# Altium schematic sheet PWM_Signal_Amplifiers.SchDoc
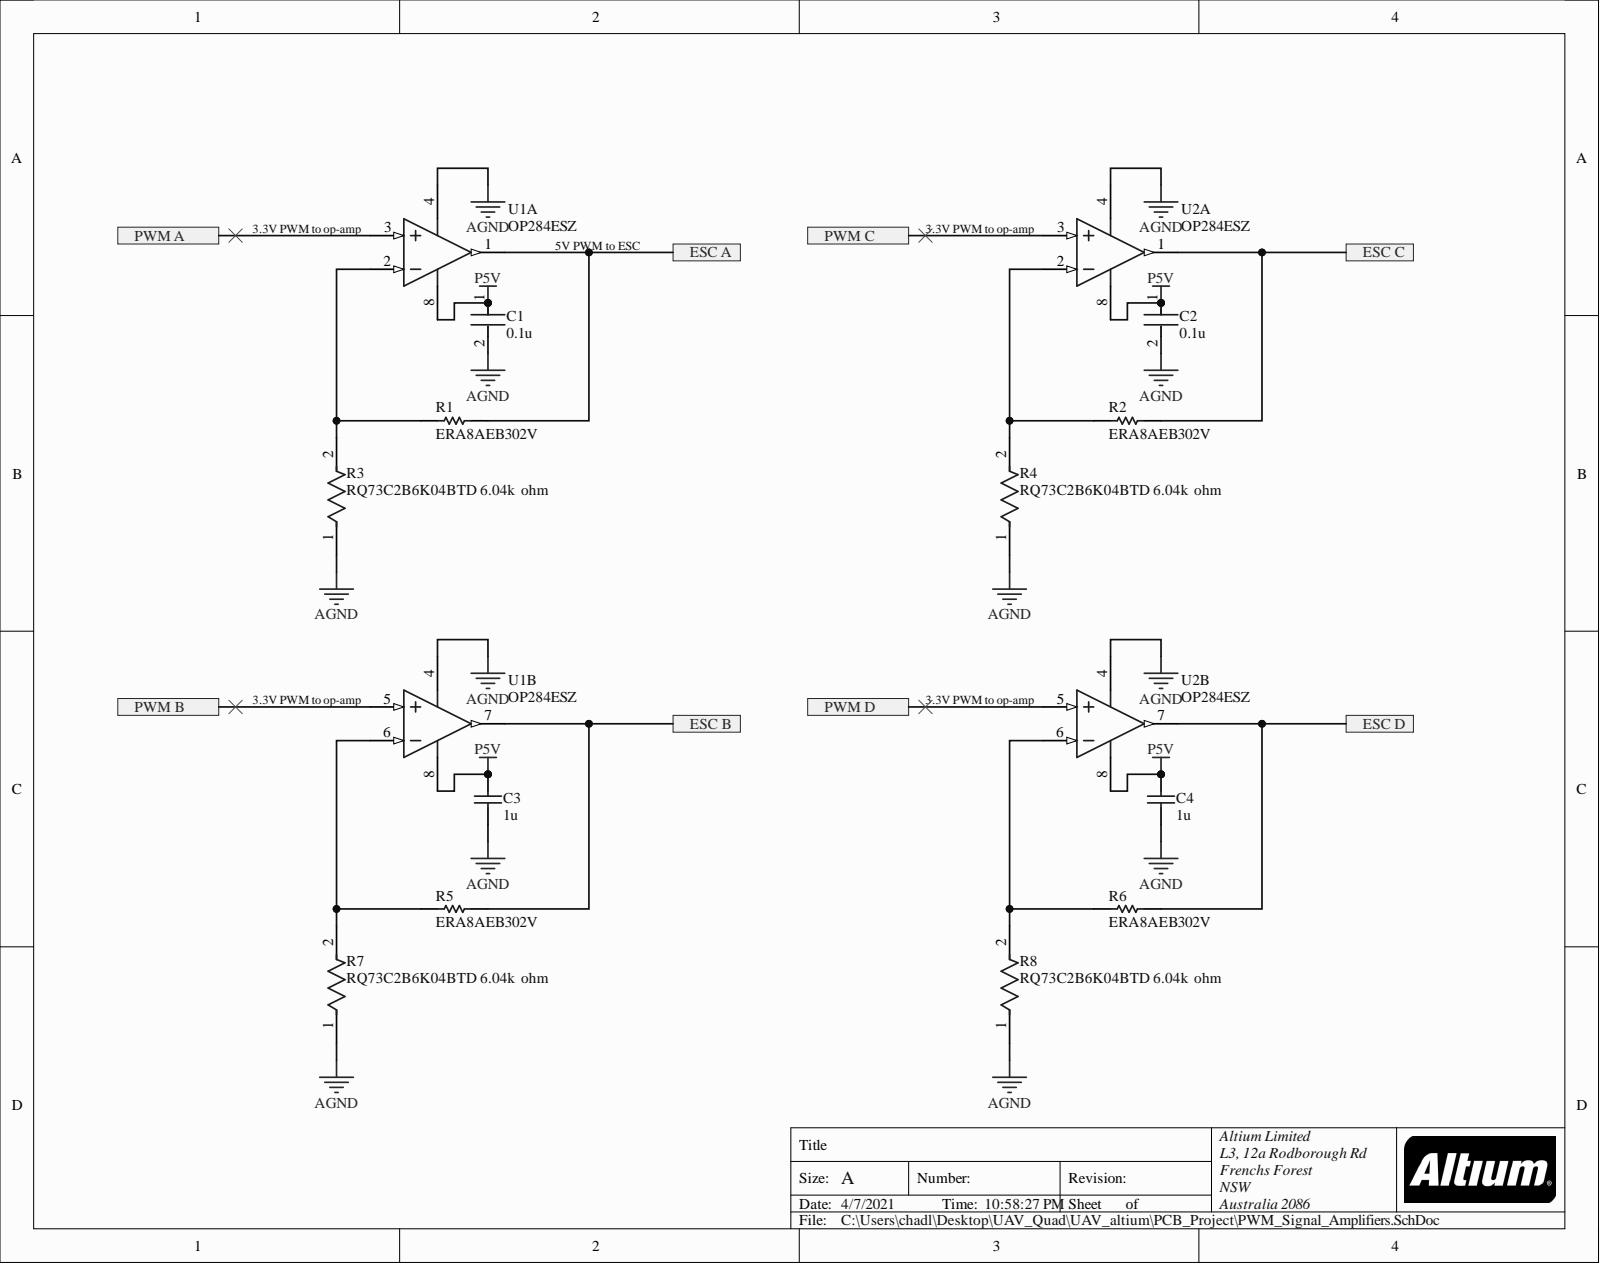
[diagram p0: PWM_Signal_Amplifiers.SchDoc]

## Parts
C1  0.1u  [FP-C0402-BB-MFG]
C2  0.1u  [FP-C0402-BB-MFG]
C3  1u  [CAPC3216X110X50LL20T25]
C4  1u  [CAPC3216X110X50LL20T25]
R1  ERA8AEB302V  [RESC3216X70X50ML20T25]
R2  ERA8AEB302V  [RESC3216X70X50ML20T25]
R3  RQ73C2B6K04BTD 6.04k  ohm  Manufacturer Part Number: RQ73C2B6K04BTD  [FP-RQ732B-IPC_C]
R4  RQ73C2B6K04BTD 6.04k  ohm  Manufacturer Part Number: RQ73C2B6K04BTD  [FP-RQ732B-IPC_C]
R5  ERA8AEB302V  [RESC3216X70X50ML20T25]
R6  ERA8AEB302V  [RESC3216X70X50ML20T25]
R7  RQ73C2B6K04BTD 6.04k  ohm  Manufacturer Part Number: RQ73C2B6K04BTD  [FP-RQ732B-IPC_C]
R8  RQ73C2B6K04BTD 6.04k  ohm  Manufacturer Part Number: RQ73C2B6K04BTD  [FP-RQ732B-IPC_C]
U1  OP284ESZ  [ADI-R-8_L]  (parts A,B)
U2  OP284ESZ  [ADI-R-8_L]  (parts A,B)

## Ports
ESC A, ESC B, ESC C, ESC D, PWM A, PWM B, PWM C, PWM D

## Nets
AGND: C1.2 C2.2 C3.1 C4.1 R3.1 R4.1 R7.1 R8.1 U1.4 U2.4
P5V: C1.1 C2.1 C3.2 C4.2 U1.8 U2.8
ESC A: R1.2 U1.1 ; port ESC A
ESC B: R5.2 U1.7 ; port ESC B
ESC C: R2.2 U2.1 ; port ESC C
ESC D: R6.2 U2.7 ; port ESC D
PWM A: U1.3 ; port PWM A
PWM B: U1.5 ; port PWM B
PWM C: U2.3 ; port PWM C
PWM D: U2.5 ; port PWM D
NetR1_1: R1.1 R3.2 U1.2
NetR2_1: R2.1 R4.2 U2.2
NetR5_1: R5.1 R7.2 U1.6
NetR6_1: R6.1 R8.2 U2.6

## Library data of the parts
C1 C2 = CMP-2008-02046-2: CAP CER 0.1UF 10V X5R 0402; Manufacturer: Kemet; Manufacturer Part Number: C0402C104K8PACTU; Capacitance: 0.10 μF; Material: Ceramic; Temperature Coefficient: 15%; Tolerance: 0.1; Value: 0.10 μF; Automotive: No; Category: Cap; Component Kind: Standard; ComponentLink2Description: Datasheet; ComponentLink2URL: https://content.kemet.com/datasheets/KEM_C1002_X7R_SMD.pdf; Device Class L1: Passive Components; Device Class L2: Capacitors; Device Class L3: Ceramic Capacitors; Digikey Description: CAP CER 0.1UF 10V X5R 0402; Height: 0.55mm; Ipc Land Pattern Name: CAPC10050X50; Lead Free: Yes; Library Name: Altium Content Vault; Mouser Description: Capacitor 100 nF 10 VDC 0402; Octopart: https://octopart.com/c0402c104k8pactu-kemet-140039; Package: C0402-BB; RoHS: TRUE; Temperature: -55°C to +125°C; Temperature Characteristic: X5R; Temperature Range High: +125°C; Temperature Range Low: -55°C; Voltage Rating: 10 V
C3 C4 = CMP-2000-06011-1: Chip Capacitor, 1 uF, +/- 10%, 50 V, -55 to 125 degC, 1206 (3216 Metric), RoHS, Tape and Reel; Brand: Kemet; Capacitance: 1uF; Capacitance - nF: 1000nF; Case Height: 1mm; Case Length: 3.2mm; Case Width: 1.6mm; Class: Class1; Dielectric: X7R; Temperature Coefficient / Code: +/-15%; Type: SMDMLCCX7R6.3-250VDCCommericalGrade; Voltage Rating: 50V; Case Code - in: 1206; Case Code - mm: 3216; Component Kind: Standard; Datasheet URL: http://www.kemet.com/docfinder?Partnumber=C1206C105K5RACTU; Datasheet Version: 02/2016; Dimensions: 1.6mmWx3.2mmLx1mmH; Factory Pack Quantity: 2500; Library Name: Altium Content Vault; Manufacturer: Kemet; Manufacturer URL: http://www.kemet.com/; Maximum Operating Temperature: +125C; Minimum Operating Temperature: -55C; Mounting Technology: SurfaceMount; Operating Temperature Range: -55Cto+125C; Package / Case: 1206(3216metric); Package Description: 2-Pin Surface Mount Device, Body 3.2 x 1.6 mm; Package Reference: 1206; Packaging: Reel; Product: GeneralTypeMLCCs; Product Category: MultilayerCeramicCapacitorsMLCC-SMD/SMT; RoHS: Details; Series: C1206C; Termination Style: SMD/SMT; Tolerance: 10%; Voltage Rating DC: 50V
R1 R2 R5 R6 = CMP-2003-04451-1: Manufacturer: Panasonic; Component Kind: Standard; Library Name: Altium Content Vault; Part Number: ERA8AEB302V
R3 R4 R7 R8 = CMP-03211-007803-1: RQ732B 1206 6.04KΩ 0.4W 10ppm/°C 0.1% 200V; Manufacturer: TE; Automotive Grade: Grade 0; Automotive: Yes; Category: Res; Component Kind: Standard; ComponentLink2Description: Datasheet; ComponentLink2URL: https://www.te.com/commerce/DocumentDelivery/DDEController?Action=showdoc&DocId=Data+Sheet%7F1773270-2%7FA%7Fpdf%7FEnglish%7FENG_DS_1773270-2_A.pdf%7F9-2176388-439; Composition: Thin Film; Device Class L1: Passive Components; Device Class L2: Resistors; Device Class L3: Chip SMD Resistors; Height: 0.75mm; Ipc Land Pattern Name: RESC310160X60; Lead Free: Yes; Library Name: Altium Content Vault; Octopart: https://octopart.com/rq73c2b6k04btd-te+connectivity-93912055; Package: RQ732B; Power Rating: 0.4W; Resistance: 6.04KΩ; RoHS: TRUE; Series: RQ73; Temperature: -55°C to +155°C; Temperature Coefficient: 10ppm/°C; Temperature Range High: +155°C; Temperature Range Low: -55°C; Tolerance: 0.1%; Value: 6.04KΩ; Voltage Rating: 200V
U1 U2 = CMP-0384-00701-1: Double Channel Single-Supply Operation Operational Amplifier, 4.25 MHz BW, 4 V/us SR, Industrial, 8-pin SOIC (R-8), Tube; Generic Part Number: OP284; Manufacturer: Analog Devices; Amplifiers Per Package: 2; Input Bias Current: 80nA; Input Offset Voltage: 175uV; Iq/Amp: 2mA; Slew Rate: 4V/us; V Span Max: 36V; V Span Min: 3V; Code_JEDEC: MS-012-AA; Component Kind: Standard; ComponentLink1Description: Manufacturer URL; ComponentLink1URL: http://www.analog.com/en/index.html; ComponentLink2Description: Datasheet; ComponentLink2URL: http://www.analog.com/static/imported-files/data_sheets/OP184_284_484.pdf; ComponentLink3Description: Package Specification; ComponentLink3URL: http://www.analog.com/static/imported-files/packages/PKG_PDF/SOIC_Narrow%20(R)/R_8.pdf; DatasheetVersion: Rev. J; Library Name: Altium Content Vault; Mounting Technology: Surface Mount; PackageDescription: 8-Pin Standard Small Outline Package, Body 3.9 x 4.9 mm, Pitch 1.27 mm; PackageReference: R-8; PackageVersion: 060506-A; PartNumber: OP284ESZ; Small Signal Bandwidth: 4.25MHz
